# Altium schematic sheet pca20017_misc.SchDoc
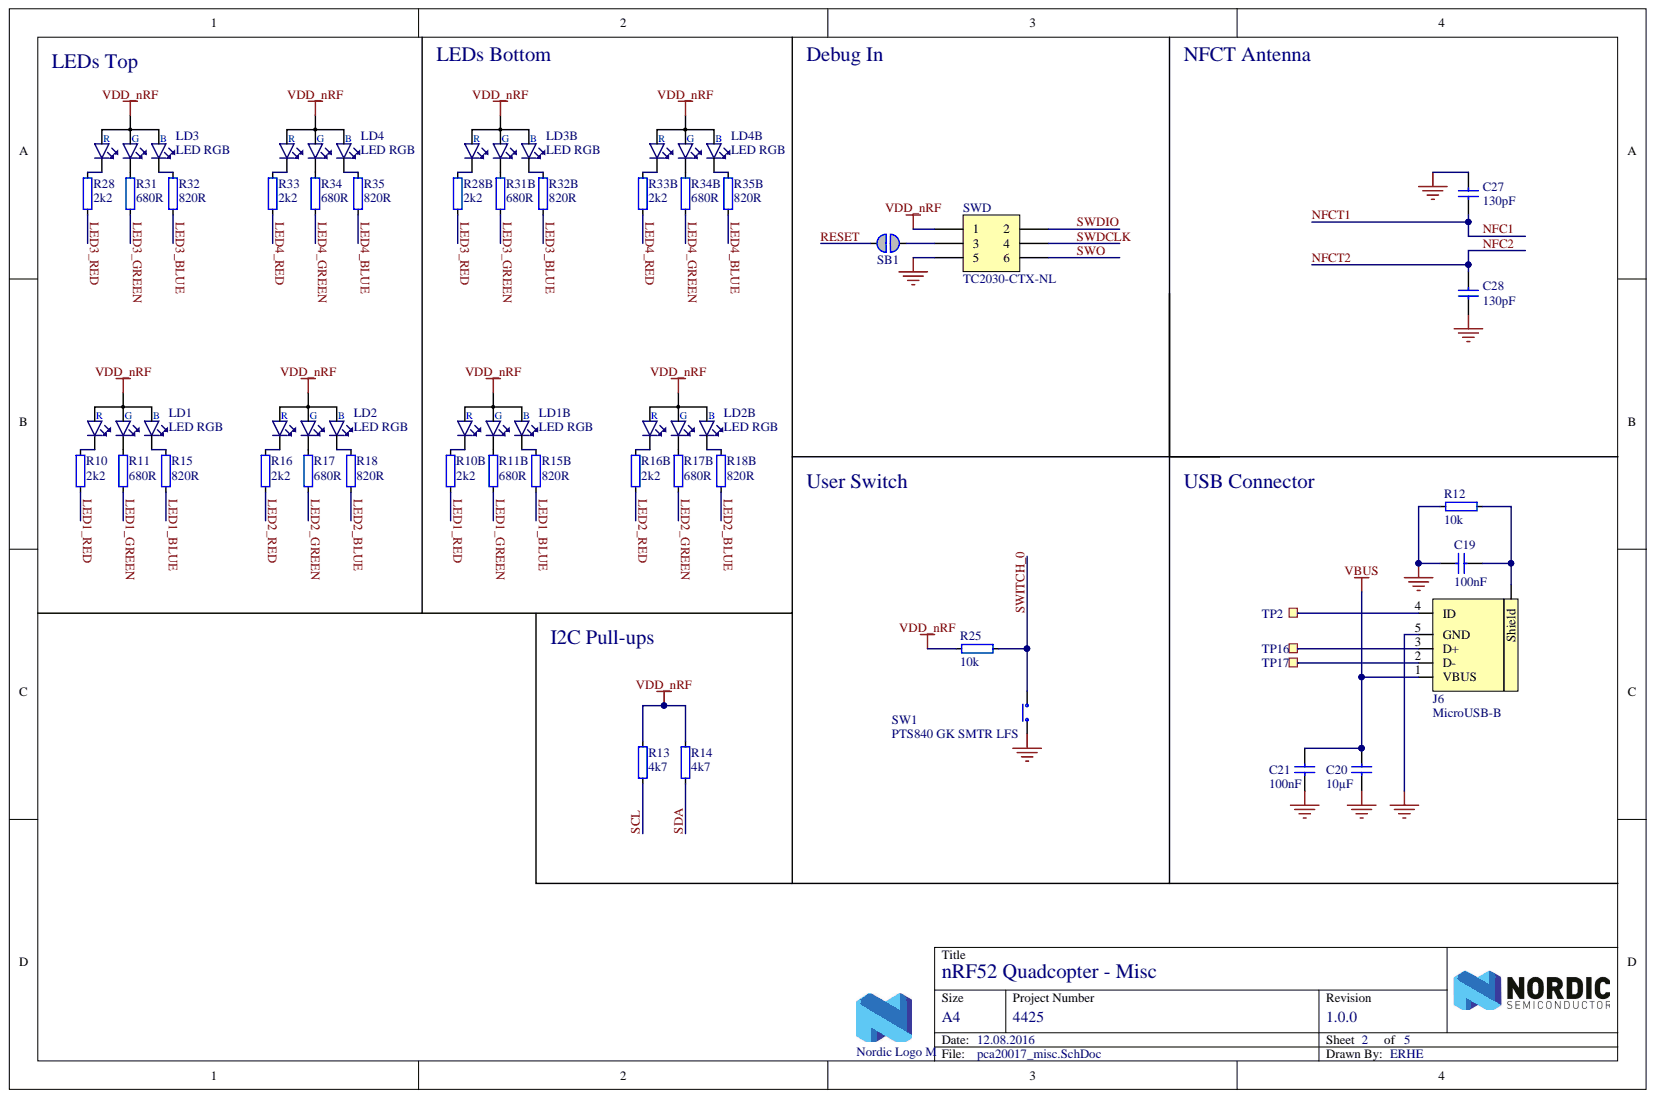
[diagram p0: pca20017_misc.SchDoc]

## Parts
C19  100nF  [CAPC1005X04L]
C20  10µF  [CAPC1608X06L]
C21  100nF  [CAPC1005X04L]
C27  130pF  [CAPC1005X04L]
C28  130pF  [CAPC1005X04L]
J6  MicroUSB-B  [USB-Micro-B-RA-SMD-PiP]
LD1  LED RGB  [SML-LX0404SIUPGUSB]
LD1B  LED RGB  [SML-LX0404SIUPGUSB]
LD2  LED RGB  [SML-LX0404SIUPGUSB]
LD2B  LED RGB  [SML-LX0404SIUPGUSB]
LD3  LED RGB  [SML-LX0404SIUPGUSB]
LD3B  LED RGB  [SML-LX0404SIUPGUSB]
LD4  LED RGB  [SML-LX0404SIUPGUSB]
LD4B  LED RGB  [SML-LX0404SIUPGUSB]
LOGO1  Nordic Logo M  [NORDIC_LOGO_M]  (no BOM)
R10  2k2  [RESC1005X04L]
R10B  2k2  [RESC1005X04L]
R11  680R  [RESC1005X04L]
R11B  680R  [RESC1005X04L]
R12  10k  [RESC1005X04L]
R13  4k7  [RESC1005X04L]
R14  4k7  [RESC1005X04L]
R15  820R  [RESC1005X04L]
R15B  820R  [RESC1005X04L]
R16  2k2  [RESC1005X04L]
R16B  2k2  [RESC1005X04L]
R17  680R  [RESC1005X04L]
R17B  680R  [RESC1005X04L]
R18  820R  [RESC1005X04L]
R18B  820R  [RESC1005X04L]
R25  10k  [RESC1005X04L]
R28  2k2  [RESC1005X04L]
R28B  2k2  [RESC1005X04L]
R31  680R  [RESC1005X04L]
R31B  680R  [RESC1005X04L]
R32  820R  [RESC1005X04L]
R32B  820R  [RESC1005X04L]
R33  2k2  [RESC1005X04L]
R33B  2k2  [RESC1005X04L]
R34  680R  [RESC1005X04L]
R34B  680R  [RESC1005X04L]
R35  820R  [RESC1005X04L]
R35B  820R  [RESC1005X04L]
SB1  Solderbridge  [Solderbridge]  (value not drawn)  (no BOM)
SW1  PTS840 GK SMTR LFS  [EVQP7A/J/B/K]
SWD  TC2030-CTX-NL  [TC2030-IDC-NLFP]  (no BOM)
TP2  Ø1.0mm,SMD  [TP_SMD_Ø1.0mm]  (value not drawn)  (no BOM)
TP16  Ø1.0mm,SMD  [TP_SMD_Ø1.0mm]  (value not drawn)  (no BOM)
TP17  Ø1.0mm,SMD  [TP_SMD_Ø1.0mm]  (value not drawn)  (no BOM)

## Nets
GND: C19.1 C20.1 C21.1 C27.2 C28.1 J6.5(GND) R12.1 SW1.2 SWD.5
VBUS: C20.2 C21.2 J6.1(VBUS)
VDD_NRF: LD1.4 LD1B.4 LD2.4 LD2B.4 LD3.4 LD3B.4 LD4.4 LD4B.4 R13.2 R14.2 R25.1 SWD.1
LED1_BLUE: R15.1 R15B.1
LED1_GREEN: R11.1 R11B.1
LED1_RED: R10.1 R10B.1
LED2_BLUE: R18.1 R18B.1
LED2_GREEN: R17.1 R17B.1
LED2_RED: R16.1 R16B.1
LED3_BLUE: R32.1 R32B.1
LED3_GREEN: R31.1 R31B.1
LED3_RED: R28.1 R28B.1
LED4_BLUE: R35.1 R35B.1
LED4_GREEN: R34.1 R34B.1
LED4_RED: R33.1 R33B.1
NFC1: C27.1
NFC2: C28.2
RESET: SB1.2
SCL: R13.1
SDA: R14.1
SWDCLK: SWD.4
SWDIO: SWD.2
SWITCH_0: R25.2 SW1.1
SWO: SWD.6
NetC19_2: C19.2 J6.6(Shield) R12.2
NetJ6_2: J6.2(D-) TP17.1
NetJ6_3: J6.3(D+) TP16.1
NetJ6_4: J6.4(ID) TP2.1
NetLD1_1: LD1.1 R10.2
NetLD1_2: LD1.2 R11.2
NetLD1_3: LD1.3 R15.2
NetLD1B_1: LD1B.1 R10B.2
NetLD1B_2: LD1B.2 R11B.2
NetLD1B_3: LD1B.3 R15B.2
NetLD2_1: LD2.1 R16.2
NetLD2_2: LD2.2 R17.2
NetLD2_3: LD2.3 R18.2
NetLD2B_1: LD2B.1 R16B.2
NetLD2B_2: LD2B.2 R17B.2
NetLD2B_3: LD2B.3 R18B.2
NetLD3_1: LD3.1 R28.2
NetLD3_2: LD3.2 R31.2
NetLD3_3: LD3.3 R32.2
NetLD3B_1: LD3B.1 R28B.2
NetLD3B_2: LD3B.2 R31B.2
NetLD3B_3: LD3B.3 R32B.2
NetLD4_1: LD4.1 R33.2
NetLD4_2: LD4.2 R34.2
NetLD4_3: LD4.3 R35.2
NetLD4B_1: LD4B.1 R33B.2
NetLD4B_2: LD4B.2 R34B.2
NetLD4B_3: LD4B.3 R35B.2
NetSB1_1: SB1.1 SWD.3

## Library data of the parts
C19 C21 = C0402-100n: Capacitor, X7R, ±10%; Artikkelnr Noca: 20039200; Artikkelnr Simpro: N.A.; Database Table Name: Capacitors$; Note: 16V; Component Kind: Standard; Footprint Doc: 0402; LatestRevisionDate: 2009-11-23; Library Name: Nordic Passive DB.DbLib; Part Number: C0402-100n; Pin Count: 2; Published: 2009-05-08; Value: 100nF; Publisher: Nordic Semiconductor
C20 = C0603-10µ: Capacitor, X5R, ±20%; Artikkelnr Noca: 20039234; Artikkelnr Simpro: N.A.; Database Table Name: Capacitors$; Note: 6.3V; Component Kind: Standard; Footprint Doc: 0603; LatestRevisionDate: 2011-01-17; Library Name: Nordic Passive DB.DbLib; Part Number: C0603-10µ; Pin Count: 2; Published: 2011-01-17; Value: 10µF; Publisher: Nordic Semiconductor
C27 C28 = C0402-130p: Chip Cap 0402; Artikkelnr Noca: N.A.; Database Table Name: Capacitors$; Note: Chip Cap 1%; Artikkelnr Simpro: N.A.; Component Kind: Standard; Footprint Doc: 0402; LatestRevisionDate: 2015-04-24; Library Name: Nordic Passive DB.DbLib; Part Number: C0402-130p; Pin Count: 2; Published: 2015-04-24; Value: 130pF; Publisher: Nordic Semiconductor
J6 = USB-Micro-B-RA-SMD-PiP: MicroUSB-B-SMT; 2nd source part number: 609-4616-1-ND; Manufacturer: Allen Creations Corp.; Manufacturer Part Number: AJU55-BS2111-L; Artikkelnr Noca: 55029020; Artikkelnr Simpro: N.A.; Database Table Name: Connectors$; 2nd source Supplier: Digi-Key; Component Kind: Standard; Datasheet: \\hizz\pro\app9717\Datasheet\connectores\Allen Creations Corp\AJU-211.pdf; Footprint Doc: USB-Micro-B-RA-SMD-PiP; LatestRevisionDate: 2016-04-21; Library Name: Nordic Passive DB.DbLib; Part Number: USB-Micro-B-RA-SMD-PiP; Pin Count: 5; Published: 2014-10-07; Supplier: Cabcon; Supplier part number: AJU55-BS2111-L; Value: MicroUSB-B; Publisher: Nordic Semiconductor
LD1 LD1B LD2 LD2B LD3 LD3B LD4 LD4B = SML-LX0404SIUPGUSB: RGB LED 1.00 mm x 1.00 mm SMD; 2nd source part number: 211-8843; Manufacturer: Lumex; Artikkelnr Noca: 13019150; Artikkelnr Simpro: N.A.; Database Table Name: Optoelectronics$; 2nd source Supplier: Farnell; Component Kind: Standard; Datasheet: http://media.digikey.com/pdf/Data%20Sheets/Lumex%20PDFs/SML-LX0404SIUPGUSB.pdf; Footprint Doc: SML-LX0404SIUPGUSB; LatestRevisionDate: 2012-10-08; Library Name: Nordic Discrete DB.DbLib; Manufacturer Part Number: SML-LX0404SIUPGUSB; Part Number: SML-LX0404SIUPGUSB; Pin Count: 4; Published: 2012-10-08; Supplier: Digi-Key; Supplier part number: 67-2125-1-ND; Value: LED RGB; Publisher: Nordic Semiconductor
LOGO1 = Nordic Logo M: Nordic Logo, medium size; Artikkelnr Noca: N.A.; Database Table Name: Connectors$; Artikkelnr Simpro: N.A.; Footprint Doc: NORDIC_LOGO_M; LatestRevisionDate: 2010-02-18; Library Name: Nordic Passive DB.DbLib; Part Number: Nordic Logo M; Pin Count: 2; Published: 2010-02-18; Value: Nordic Logo M; Publisher: Nordic Semiconductor
R10 R10B R16 R16B R28 R28B R33 R33B = R0402-2k2: Resistor, ±1%, 0.063W; Artikkelnr Noca: 27049081; Artikkelnr Simpro: N.A.; Database Table Name: Resistors$; Note: Chip Res 1%; Value Ohm: 2200; Component Kind: Standard; Footprint Doc: 0402; LatestRevisionDate: 2009-11-23; Library Name: Nordic Passive DB.DbLib; Part Number: R0402-2k2; Pin Count: 2; Published: 2009-05-08; Supplier: Farnell; Supplier part number: 173-8854; Value: 2k2; Publisher: Nordic Semiconductor
R11 R11B R17 R17B R31 R31B R34 R34B = R0402-680: Resistor, ±1%, 0.063W; Artikkelnr Noca: 27049069; Artikkelnr Simpro: N.A.; Database Table Name: Resistors$; Note: Chip Res 1%; Value Ohm: 680; Component Kind: Standard; Footprint Doc: 0402; LatestRevisionDate: 2009-11-23; Library Name: Nordic Passive DB.DbLib; Part Number: R0402-680; Pin Count: 2; Published: 2009-05-08; Supplier: Farnell; Supplier part number: 173-8848; Value: 680R; Publisher: Nordic Semiconductor
R12 R25 = R0402-10k: Resistor, ±1%, 0.063W; Artikkelnr Noca: 27049097; Artikkelnr Simpro: N.A.; Database Table Name: Resistors$; Note: Chip Res 1%; Value Ohm: 10000; Component Kind: Standard; Footprint Doc: 0402; LatestRevisionDate: 2009-11-23; Library Name: Nordic Passive DB.DbLib; Part Number: R0402-10k; Pin Count: 2; Published: 2009-05-08; Supplier: Farnell; Supplier part number: 173-8864; Value: 10k; Publisher: Nordic Semiconductor
R13 R14 = R0402-4k7: Resistor, ±1%, 0.063W; Artikkelnr Noca: 27049089; Artikkelnr Simpro: N.A.; Database Table Name: Resistors$; Note: Chip Res 1%; Value Ohm: 4700; Component Kind: Standard; Footprint Doc: 0402; LatestRevisionDate: 2009-11-23; Library Name: Nordic Passive DB.DbLib; Part Number: R0402-4k7; Pin Count: 2; Published: 2009-05-08; Supplier: Farnell; Supplier part number: 173-8858; Value: 4k7; Publisher: Nordic Semiconductor
R15 R15B R18 R18B R32 R32B R35 R35B = R0402-820: Resistor, ±1%, 0.063W; Artikkelnr Noca: 27049071; Artikkelnr Simpro: N.A.; Database Table Name: Resistors$; Note: Chip Res 1%; Value Ohm: 820; Component Kind: Standard; Footprint Doc: 0402; LatestRevisionDate: 2009-11-23; Library Name: Nordic Passive DB.DbLib; Part Number: R0402-820; Pin Count: 2; Published: 2009-05-08; Supplier: Farnell; Supplier part number: 173-8849; Value: 820R; Publisher: Nordic Semiconductor
SB1 = Solderbridge: Artikkelnr Noca: N.A.; Database Table Name: Connectors$; Artikkelnr Simpro: N.A.; Description: Solderbridge; Footprint Doc: Solderbridge; LatestRevisionDate: 2009-12-14; Library Name: Nordic Passive DB.DbLib; Part Number: Solderbridge; Pin Count: 2; Published: 2009-12-14; Value: Solderbridge; Publisher: Nordic Semiconductor
SW1 = PTS840 GK SMTR LFS: Microminiature SMT Switch, Side Actuated; 2nd source part number: 611-PTS840GKSMTR; Manufacturer: C & K; Artikkelnr Noca: 50019089; Artikkelnr Simpro: N.A.; Database Table Name: Rele_Switch$; Note: Tactile Switch, SPST, SMD, 220gf; 2nd source Supplier: Mouser; Component Kind: Standard; Datasheet: http://www.ck-components.com/80185/pts840_11june13.pdf/; Footprint Doc: PTS840 Gx; LatestRevisionDate: 2014-09-17; Library Name: Nordic Discrete DB.DbLib; Manufacturer Part Number: PTS840 GK SMTR LFS; Part Number: PTS840 GK SMTR LFS; Pin Count: 4; Published: 2014-08-12; Supplier: Digi-Key; Supplier part number: CKN10508CT-ND; Value: PTS840 GK SMTR LFS; Publisher: Nordic Semiconductor
SWD = TC2030-CTX-NL: CBL PLUG-OF-NAILS 6-PIN; Manufacturer: Tag-Connect LLC; Artikkelnr Noca: N.A.; Database Table Name: Connectors$; Artikkelnr Simpro: N.A.; Datasheet: http://www.tag-connect.com/Materials/TC2030-IDC-NL.pdf; Footprint Doc: TC2030-IDC-NLFP; LatestRevisionDate: 2013-08-08; Library Name: Nordic Passive DB.DbLib; Manufacturer Part Number: TC2030-CTX-NL; Part Number: TC2030-CTX-NL; Pin Count: 6; Published: 2013-08-08; Supplier: Tag-Connect; Supplier part number: TC2030-CTX-NL; Value: TC2030-CTX-NL; Publisher: Nordic Semiconductor
TP2 TP16 TP17 = TP_SMD_Ø1.0MM: 1.0mm circular SMD testpad; Manufacturer: Nordic Semiconductor; Artikkelnr Noca: N.A.; Database Table Name: Connectors$; Artikkelnr Simpro: N.A.; Footprint Doc: TP_SMD_Ø1.0mm; LatestRevisionDate: 2013-06-11; Library Name: Nordic Passive DB.DbLib; Part Number: TP_SMD_Ø1.0MM; Pin Count: 1; Published: 2013-06-11; Value: Ø1.0mm,SMD; Publisher: Nordic Semiconductor
